# Altium schematic sheet TopLevel.SchDoc
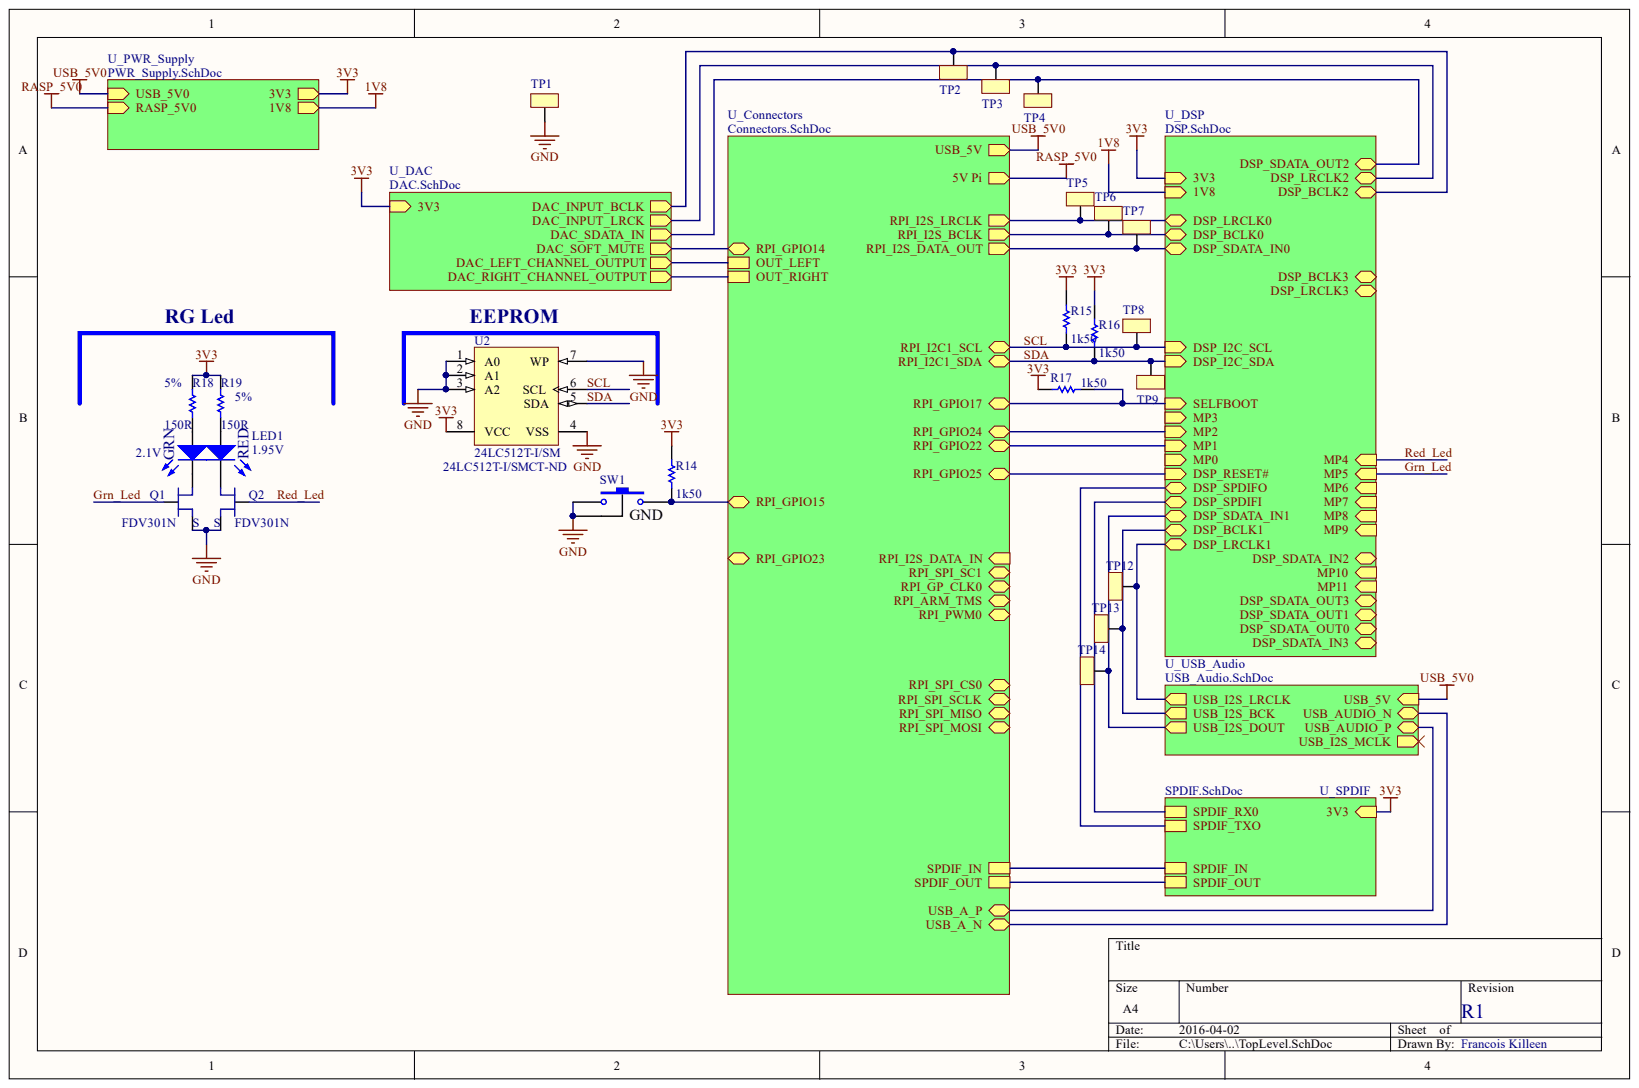
[diagram p0: TopLevel.SchDoc]

## Parts
LED1  VF Green: 2.1V  VF Red: 1.95V  [LED - Bicolor]  (hidden comment: LED-GRN200/RED80-0805)
Q1  PN: FDV301N  [SOT23]  (hidden comment: TRANS-MOSFET-N-220MA-SOT23)
Q2  PN: FDV301N  [SOT23]  (hidden comment: TRANS-MOSFET-N-220MA-SOT23)
R14  value 1k50  [1608[0603]]  (hidden comment: RES-1K5-0603-5)
R15  value 1k50  [1608[0603]]  (hidden comment: RES-1K5-0603-5)
R16  value 1k50  [1608[0603]]  (hidden comment: RES-1K5-0603-5)
R17  value 1k50  [1608[0603]]  (hidden comment: RES-1K5-0603-5)
R18  value 150R  Tolerance: 5%  [1608[0603]]  (hidden comment: RES-150R-0603-5)
R19  value 150R  Tolerance: 5%  [1608[0603]]  (hidden comment: RES-150R-0603-5)
SW1  SW-PB-SPST-NO-6MM-SMT  [SW_Pushbutton]  (value not drawn)
TP1  MEC-TESTPOINT-0.40-RED  [TESTPOINT]  (value not drawn)
TP2  MEC-TESTPOINT-0.40-RED  [TESTPOINT_MINI]  (value not drawn)
TP3  MEC-TESTPOINT-0.40-RED  [TESTPOINT_MINI]  (value not drawn)
TP4  MEC-TESTPOINT-0.40-RED  [TESTPOINT_MINI]  (value not drawn)
TP5  MEC-TESTPOINT-0.40-RED  [TESTPOINT_MINI]  (value not drawn)
TP6  MEC-TESTPOINT-0.40-RED  [TESTPOINT_MINI]  (value not drawn)
TP7  MEC-TESTPOINT-0.40-RED  [TESTPOINT_MINI]  (value not drawn)
TP8  MEC-TESTPOINT-0.40-RED  [TESTPOINT_MINI]  (value not drawn)
TP9  MEC-TESTPOINT-0.40-RED  [TESTPOINT_MINI]  (value not drawn)
TP12  MEC-TESTPOINT-0.40-RED  [TESTPOINT_MINI]  (value not drawn)
TP13  MEC-TESTPOINT-0.40-RED  [TESTPOINT_MINI]  (value not drawn)
TP14  MEC-TESTPOINT-0.40-RED  [TESTPOINT_MINI]  (value not drawn)
U2  24LC512T-I/SM  #digikey: 24LC512T-I/SMCT-ND  [SOIJ-SM8_L]

## Nets
3V3: R14.1 R15.2 R16.2 R17.2 R18.1 R19.1 U2.8(VCC)
GND: Q1.2 Q2.2 SW1.0 SW1.1 TP1.1 U2.1(A0) U2.2(A1) U2.3(A2) U2.4(VSS) U2.7(WP)
GRN_LED: Q1.1
RED_LED: Q2.1
SCL: R15.1 TP8.1 U2.6(SCL)
SDA: R16.1 TP9.1 U2.5(SDA)
NetLED1_1: LED1.1 R19.2
NetLED1_2: LED1.2 Q2.3
NetLED1_3: LED1.3 Q1.3
NetLED1_4: LED1.4 R18.2
NetR14_2: R14.2 SW1.2
NetR17_1: R17.1
NetTP2_1: TP2.1
NetTP3_1: TP3.1
NetTP4_1: TP4.1
NetTP5_1: TP5.1
NetTP6_1: TP6.1
NetTP7_1: TP7.1
NetTP12_1: TP12.1
NetTP13_1: TP13.1
NetTP14_1: TP14.1
1V8:
DSP_BCLK3:
DSP_LRCLK3:
DSP_SDATA_IN2:
DSP_SDATA_IN3:
DSP_SDATA_OUT0:
DSP_SDATA_OUT1:
DSP_SDATA_OUT3:
DSP_SPDIFI:
DSP_SPDIFO:
MP0:
MP3:
MP6:
MP7:
MP8:
MP9:
MP10:
MP11:
OUT_LEFT:
OUT_RIGHT:
RASP_5V0:
RPI_ARM_TMS:
RPI_GP_CLK0:
RPI_GPIO14:
RPI_GPIO22:
RPI_GPIO23:
RPI_GPIO24:
RPI_GPIO25:
RPI_I2S_DATA_IN:
RPI_PWM0:
RPI_SPI_CS0:
RPI_SPI_MISO:
RPI_SPI_MOSI:
RPI_SPI_SC1:
RPI_SPI_SCLK:
SPDIF_IN:
SPDIF_OUT:
USB_5V0:
USB_A_N:
USB_A_P:
USB_I2S_MCLK:

## Library data of the parts
LED1 = LED-GRN200/RED80-0805: LED 2X1.2MM RD/GN WTR CLR SMD; Brightness: 200/80; Color: GRN/RED; currentTest: 20mA; MAN: Kingbright; PN: APHBM2012SURKCGKC; #digikey: 754-1093-1-ND; Component Kind: Standard; Price: 0,44; Supplier 1: Digi-Key; Library Name: Librairie WM 2015.IntLib
Q1 Q2 = TRANS-MOSFET-N-220MA-SOT23: MOSFET N-CH 25V 220MA SOT-23; MAN: Fairchild; Power: 350mW; #digikey: FDV301NCT-ND; Component Kind: Standard; Current: 220mA; Package: SOT-23; Price: 0,34000; Supplier 1: Digi-Key; Supplier Part Number 1: FDV301NDKR-ND; Library Name: Librairie WM 2015.IntLib
R14-R17 = RES-1K5-0603-5: RES 1.5K OHM 1/10W 5% 0603 SMD; MAN: Stackpole Electronics Inc; PN: RMCF0603JT1K50; #digikey: RMCF0603JT1K50CT-ND; Component Kind: Standard; Power: 1/10W; Supplier 1: Digi-Key; Supplier Part Number 1: RMCF0603JT1K50CT-ND; Tolerance: 5%; Library Name: Librairie WM 2015.IntLib
R18 R19 = RES-150R-0603-5: RES 150R OHM 1/10W 5% 0603 SMD; MAN: Panasonic; PN: ERJ-3GEYJ151V; #digikey: P150GCT-ND; Component Kind: Standard; Power: 1/10W; Price: 0,043; Supplier 1: Digi-Key; Supplier Part Number 1: P150GDKR-ND; Library Name: Librairie WM 2015.IntLib
SW1 = SW-PB-SPST-NO-6MM-SMT: SWITCH 4 SPST SMT; MAN: Omron; PN: B3S-1100P; Rating: 0.05A @ 24VDC; #digikey: SW844CT-ND; Component Kind: Standard; Dimension: 6.60mm x 6.00mm; Price: 0,63; Supplier 1: Digi-Key; Supplier Part Number 1: SW844DKR-ND; Type: SPST-NO; Library Name: Librairie WM 2015.IntLib
TP1-TP9 TP12-TP14 = MEC-TESTPOINT-0.40-RED: TEST POINT PC MINI .040"D RED; MAN: Keystone Electronics; PN: 5000; #digikey: 5000K-ND; Component Kind: Standard; Price: 0,392
  TP1: Library Name: lib_wm_2010.IntLib
  TP2-TP9 TP12-TP14: Library Name: Librairie WM 2015.IntLib
U2 = CMP-0159-00565-1: 512Kbit, 400kHz, 2.5V, I2C Serial EEPROM, 8-Pin SOIC 208mil, Industrial Temperature, Tape and Reel; Component Kind: Standard; DatasheetVersion: revJ, Jul-2008; Library Name: Altium Content Vault; PackageDescription: 8-Lead Plastic Small Outline (SM) - Medium, 5.28 mm Body [SOIJ]; PackageReference: SOIJ-SM8; PackageVersion: revBB, Aug-2009
